# Altium schematic sheet Isolation.SchDoc(Isolation)
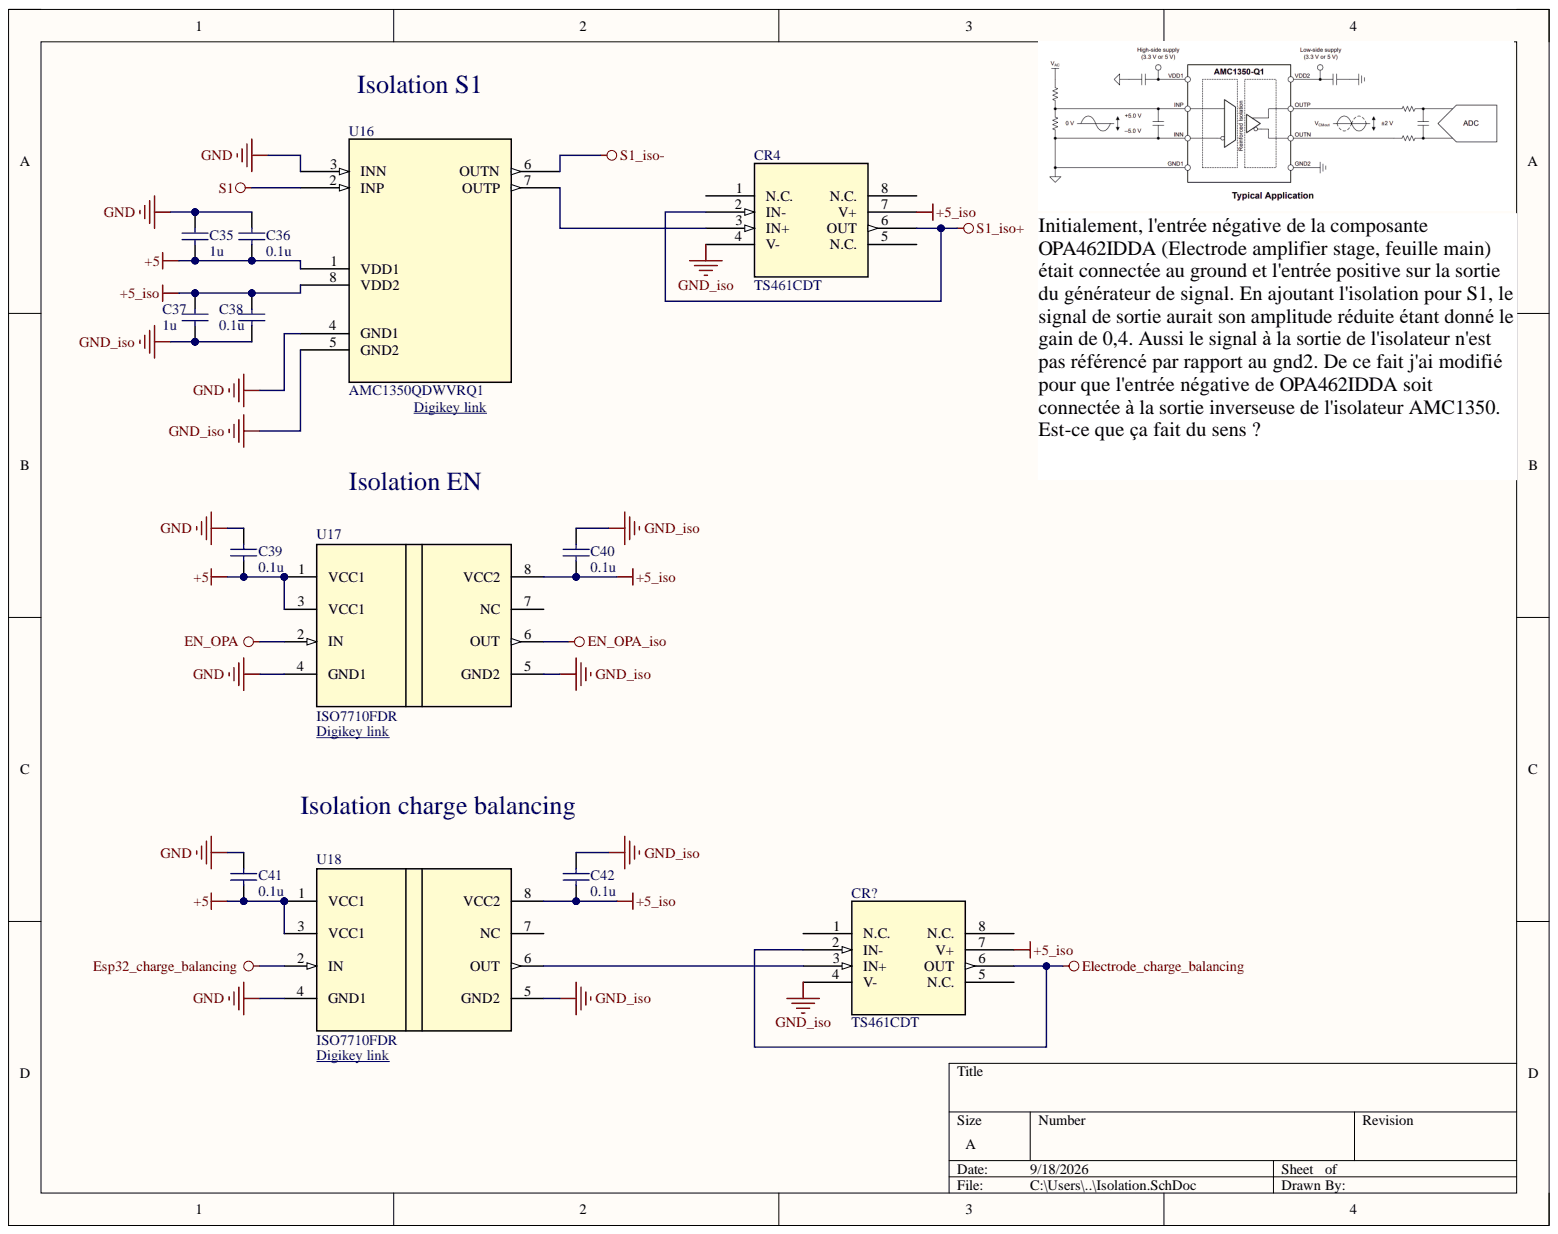
[diagram p0: Isolation.SchDoc]

## Parts
C35  value 1u  [0805_AVX]  (hidden comment: Capacitor)
C36  value 0.1u  [CAPC2012X150N]  (hidden comment: Capacitor)
C37  value 1u  [0805_AVX]  (hidden comment: Capacitor)
C38  value 0.1u  [CAPC2012X150N]  (hidden comment: Capacitor)
C39  value 0.1u  [CAPC2012X150N]  (hidden comment: Capacitor)
C40  value 0.1u  [CAPC2012X150N]  (hidden comment: Capacitor)
C41  value 0.1u  [CAPC2012X150N]  (hidden comment: Capacitor)
C42  value 0.1u  [CAPC2012X150N]  (hidden comment: Capacitor)
CR4  TS461CDT  [SO-8_STM]
CR?  TS461CDT  [SO-8_STM]
U16  AMC1350QDWVRQ1  [AMC1350QDWVRQ1_TEX]
U17  ISO7710FDR  [D0008B_N]
U18  ISO7710FDR  [D0008B_N]

## Nets
+5: C35.2 C36.1 C39.2 C41.2 U16.1(VDD1) U17.1(VCC1) U17.3(VCC1) U18.1(VCC1) U18.3(VCC1)
+5_iso: C37.1 C38.2 C40.2 C42.2 CR4.7(V+) CR?.7(V+) U16.8(VDD2) U17.8(VCC2) U18.8(VCC2)
Electrode_charge_balancing: CR?.2(IN-) CR?.6(OUT)
EN_OPA: U17.2(IN)
EN_OPA_iso: U17.6(OUT)
Esp32_charge_balancing: U18.2(IN)
GND: C35.1 C36.2 C39.1 C41.1 U16.3(INN) U16.4(GND1) U17.4(GND1) U18.4(GND1)
GND_iso: C37.2 C38.1 C40.1 C42.1 CR4.4(V-) CR?.4(V-) U16.5(GND2) U17.5(GND2) U18.5(GND2)
S1: U16.2(INP)
S1_iso+: CR4.2(IN-) CR4.6(OUT)
S1_iso-: U16.6(OUTN)
NetCR4_3: CR4.3(IN+) U16.7(OUTP)
NetCR?_3: CR?.3(IN+) U18.6(OUT)

Not connected: CR4 1(N.C.) 5(N.C.) 8(N.C.); CR? 1(N.C.) 5(N.C.) 8(N.C.); U17 7(NC); U18 7(NC)

## Library data of the parts
C35-C42 = Capacitor: SimulationGenericComponent: True; Component Kind: Standard; Description: Capacitor; Library Name: Simulation Generic Components.IntLib; Simulation: C_Capacitor_Default
CR4 CR? = TS461CDT: Output rail-to-rail op-amps; Manufacturer_Name: STMicroelectronics; Component Kind: Standard; Datasheet: https://www.st.com/resource/en/datasheet/ts461.pdf; Library Name: TS461CDT.IntLib; Manufacturer_Part_Number: TS461CDT; RefDes: RefDes; Type: Type; Copyright: Copyright (C) 2026 Ultra Librarian. All rights reserved.
U16 = AMC1350QDWVRQ1: Automotive, &plusmn;5-V input, precision voltage sensing reinforced isolated amplifier 8-SOIC -40 to 125; Manufacturer_Name: Texas Instruments; Component Kind: Standard; Library Name: AMC1350QDWVRQ1.IntLib; Manufacturer_Part_Number: AMC1350QDWVRQ1; RefDes: RefDes; Type: Type; Copyright: Copyright (C) 2026 Ultra Librarian. All rights reserved.
U17 U18 = ISO7710FDR: Robust EMC, single-channel, reinforced digital isolator 8-SOIC -55 to 125; Manufacturer_Name: Texas Instruments; Component Kind: Standard; Library Name: ISO7710FDR.IntLib; Manufacturer_Part_Number: ISO7710FDR; RefDes: RefDes; TYPE: TYPE; Copyright: Copyright (C) 2026 Ultra Librarian. All rights reserved.
